# Altium schematic sheet ArduinoShield.SchDoc(ArduinoShield)
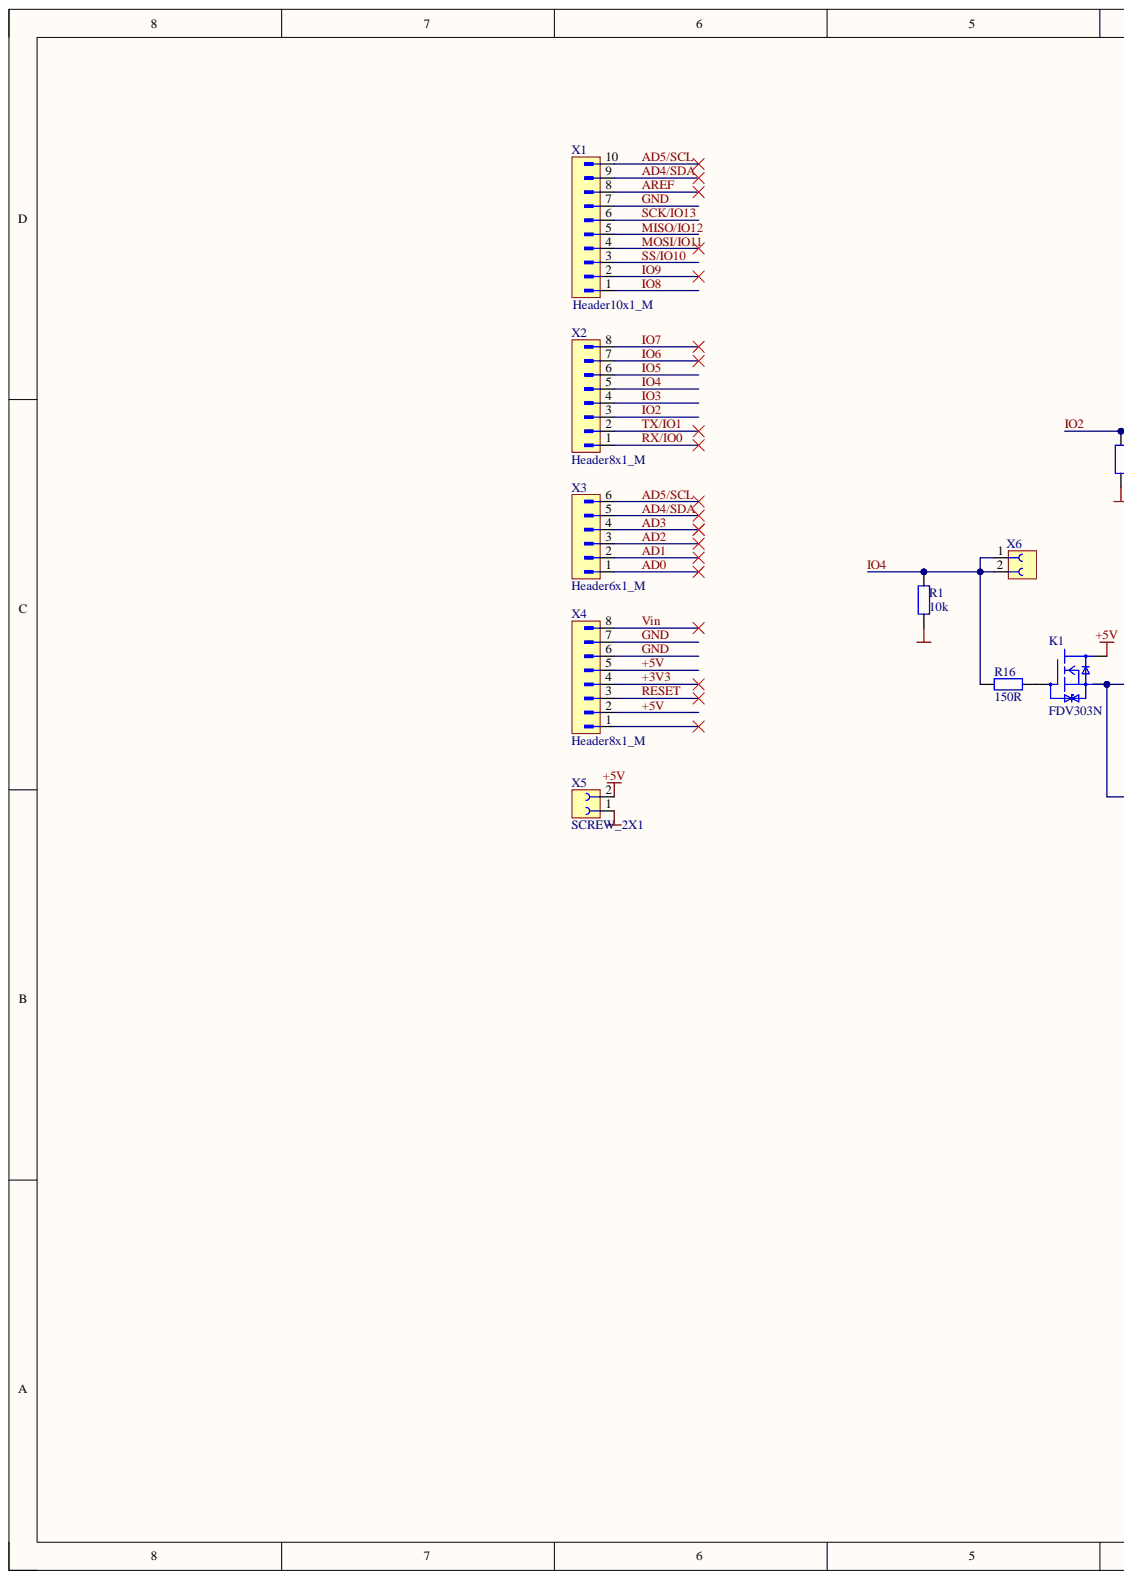
[diagram p0: ArduinoShield.SchDoc, left]
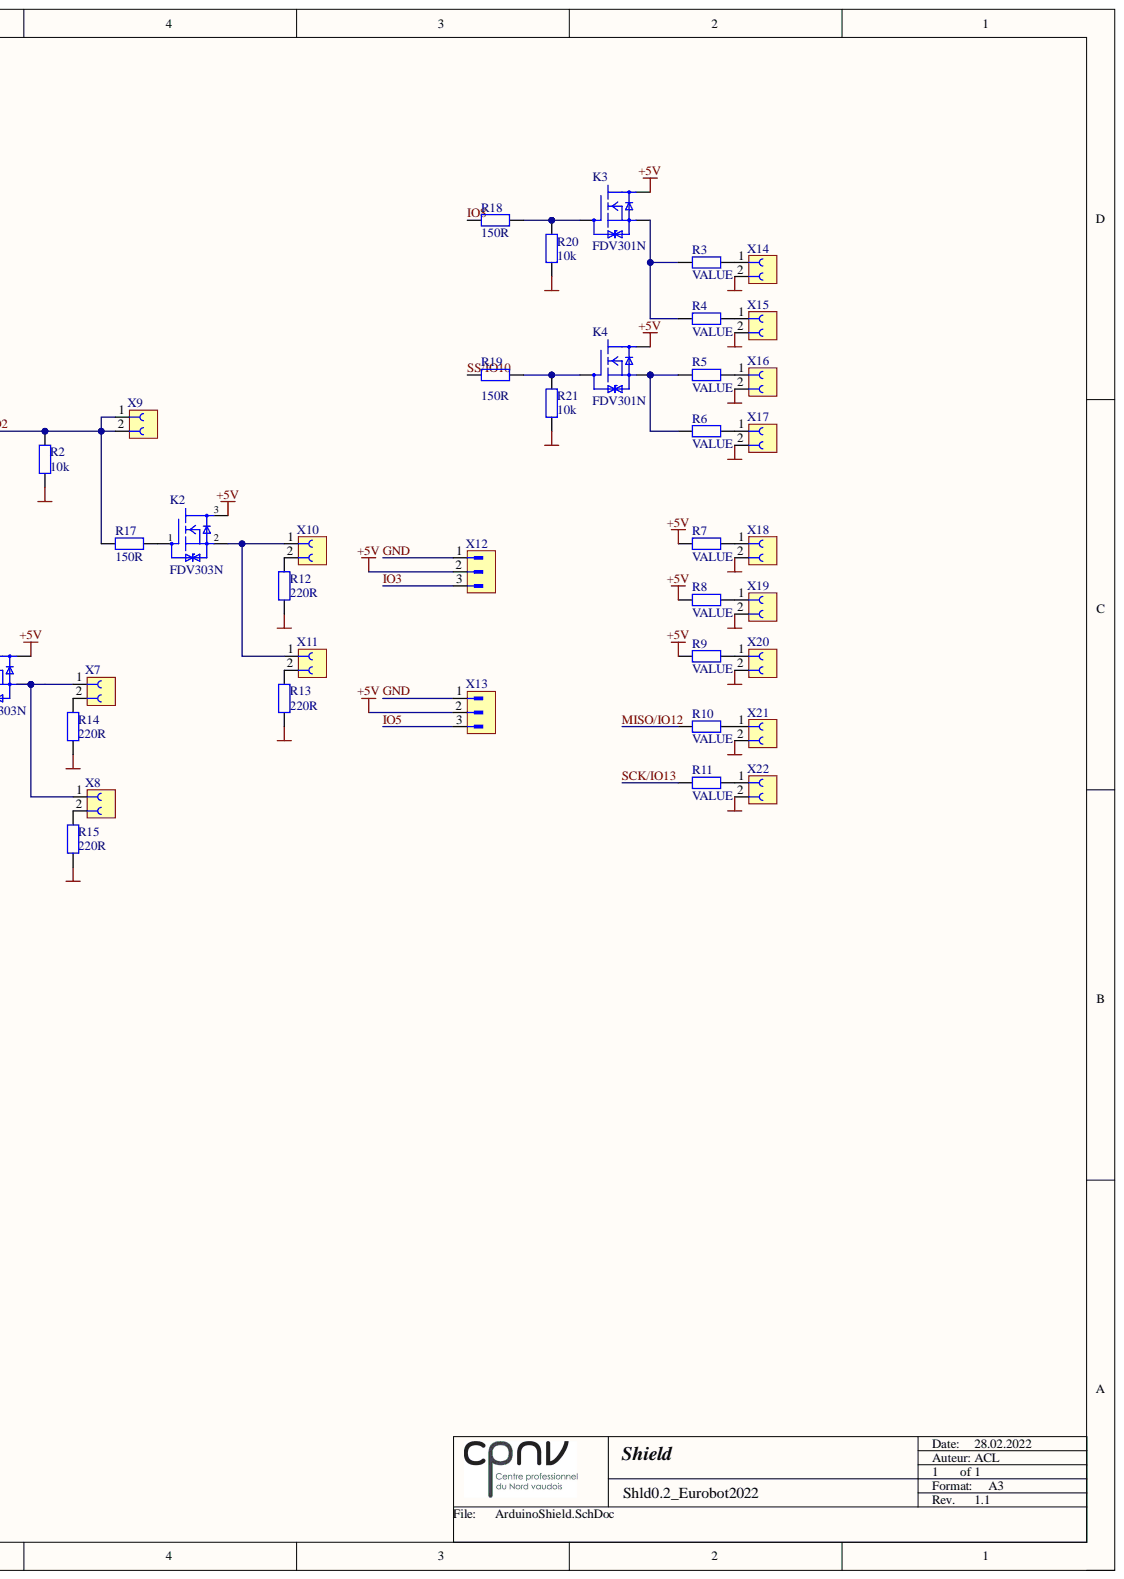
[diagram p1: ArduinoShield.SchDoc, right]

## Parts
K1  FDV303N  [SOT323]
K2  FDV303N  [SOT323]
K3  FDV301N  [SOT323]
K4  FDV301N  [SOT323]
R1  10k  [0603]
R2  10k  [0603]
R3  VALUE  [0603]
R4  VALUE  [0603]
R5  VALUE  [0603]
R6  VALUE  [0603]
R7  VALUE  [0603]
R8  VALUE  [0603]
R9  VALUE  [0603]
R10  VALUE  [0603]
R11  VALUE  [0603]
R12  220R  [0603]
R13  220R  [0603]
R14  220R  [0603]
R15  220R  [0603]
R16  150R  [0603]
R17  150R  [0603]
R18  150R  [0603]
R19  150R  [0603]
R20  10k  [0603]
R21  10k  [0603]
X1  Header10x1_M  [HDR10X1_M]
X2  Header8x1_M  [HDR8X1_M]
X3  Header6x1_M  [HDR6X1_M]
X4  Header8x1_M  [HDR8X1_M]
X5  SCREW_2X1  [691210910002]
X6  SCREW_2X1  [691210910002]
X7  SCREW_2X1  [691210910002]
X8  SCREW_2X1  [691210910002]
X9  SCREW_2X1  [691210910002]
X10  SCREW_2X1  [691210910002]
X11  SCREW_2X1  [691210910002]
X12  Header3x1_M  [HDR3X1_M]  (value not drawn)
X13  Header3x1_M  [HDR3X1_M]  (value not drawn)
X14  SCREW_2X1  [691210910002]
X15  SCREW_2X1  [691210910002]
X16  SCREW_2X1  [691210910002]
X17  SCREW_2X1  [691210910002]
X18  SCREW_2X1  [691210910002]
X19  SCREW_2X1  [691210910002]
X20  SCREW_2X1  [691210910002]
X21  SCREW_2X1  [691210910002]
X22  SCREW_2X1  [691210910002]

## Nets
+3V3: X4.4
+5V: K1.3 K2.3 K3.3 K4.3 R7.1 R8.1 R9.1 X4.2 X4.5 X5.2 X12.2 X13.2
AD0: X3.1
AD1: X3.2
AD2: X3.3
AD3: X3.4
AD4/SDA: X1.9 X3.5
AD5/SCL: X1.10 X3.6
AREF: X1.8
GND: R1.2 R2.2 R12.2 R13.2 R14.2 R15.2 R20.2 R21.2 X1.7 X4.6 X4.7 X5.1 X12.1 X13.1 X14.2 X15.2 X16.2 X17.2 X18.2 X19.2 X20.2 X21.2 X22.2
IO2: R2.1 R17.1 X2.3 X9.1 X9.2
IO3: X2.4 X12.3
IO4: R1.1 R16.1 X2.5 X6.1 X6.2
IO5: X2.6 X13.3
IO6: X2.7
IO7: X2.8
IO8: R18.1 X1.1
IO9: X1.2
MISO/IO12: R10.1 X1.5
MOSI/IO11: X1.4
RESET: X4.3
RX/IO0: X2.1
SCK/IO13: R11.1 X1.6
SS/IO10: R19.1 X1.3
TX/IO1: X2.2
Vin: X4.8
NetK1_1: K1.1 R16.2
NetK1_2: K1.2 X7.1 X8.1
NetK2_1: K2.1 R17.2
NetK2_2: K2.2 X10.1 X11.1
NetK3_1: K3.1 R18.2 R20.1
NetK3_2: K3.2 R3.1 R4.1
NetK4_1: K4.1 R19.2 R21.1
NetK4_2: K4.2 R5.1 R6.1
NetR3_2: R3.2 X14.1
NetR4_2: R4.2 X15.1
NetR5_2: R5.2 X16.1
NetR6_2: R6.2 X17.1
NetR7_2: R7.2 X18.1
NetR8_2: R8.2 X19.1
NetR9_2: R9.2 X20.1
NetR10_2: R10.2 X21.1
NetR11_2: R11.2 X22.1
NetR12_1: R12.1 X10.2
NetR13_1: R13.1 X11.2
NetR14_1: R14.1 X7.2
NetR15_1: R15.1 X8.2

Not connected: X4 1

## Library data of the parts
K1-K4 = Component_1: Component Kind: Standard; Library Name: Schlib1.SchLib
R1-R21 = RES: Resistor; Component Kind: Standard; Supplier 1: CPNV; Library Name: Passif_et_Semi-conducteurs.SchLib
X1 = CMP-031-000016-3: WURTH ELEKTRONIK - 61301011121 - Pin Header, Board-to-Board, 2.54 mm, 1 Rows, 10 Contacts, Through Hole, WR-PHD Series; Manufacturer: Wurth Electronics; Connector Type: Male Pin; Current Rating: 3A; Gender: Male; Insulation Resistance: 1GR; Number of Contacts: 10; Pitch: 2.54mm; Voltage Rating: 250V; Category: Headers and Wire Housings; Color: Black; Component Kind: Standard; ComponentLink1Description: https://datasheet.ciiva.com/738/6130xx11121-738550.pdf?src-supplier=Digi-Key; ComponentLink1URL: https://datasheet.ciiva.com/738/6130xx11121-738550.pdf?src-supplier=Digi-Key; ComponentLink2Description: https://datasheet.ciiva.com/7631/1442175-7631321.pdf?src-supplier=Element14; ComponentLink2URL: https://datasheet.ciiva.com/7631/1442175-7631321.pdf?src-supplier=Element14; ComponentLink3Description: https://datasheet.ciiva.com/48700/001088187-da-01-en-wr-phd-tht-stiftleiste-61301011121-48700876.pdf?src-supplier=Conrad; ComponentLink3URL: https://datasheet.ciiva.com/48700/001088187-da-01-en-wr-phd-tht-stiftleiste-61301011121-48700876.pdf?src-supplier=Conrad; Contact Gender: Male; Contact Material: Copper Alloy,Copper; Contact Mating Length: 0.236inch; Contact Plating: Gold; Contact Type: Male Pin; Design Item ID: Header10x1_M; Flammability Rating: UL94 V-0; Height: 11.54mm; Housing Material: PBT; Length: 2.54mm; Manufacturer Part Number: 61301011121; Max Operating Temperature: 125°C; Min Operating Temperature: -40°C; Mount: Through Hole; Number of Positions: 10; Number of Rows: 1; Orientation: Straight; Package Quantity: 1000; Packaging: Bulk; Pricing 1: 1=0.89000, 10=0.87400, 50=0.73900, 100=0.67200, 1000=0.42700, 10000=0.42700 (USD); Pricing 2: 1=0.89000, 10=0.87400, 50=0.80600, 100=0.73900, 200=0.67200 (USD); REACH SVHC: Unknown; RoHS: Yes; RoHS Compliant: Yes; Series: WR-PHD; Stock 1: 1900; Stock 2: 4313; Termination: Solder; Width: 25.4mm; Library Name: CPNV Centre professionnel du Nord vaudois; Source: MEION_Connectors.SchLib
X2 X4 = CMP-031-000015-3: Board-To-Board Connector, Vertical, Wr-Phd Series, Through Hole, Header, 8, 2.54 Mm; Manufacturer: Wurth Electronics; Manufacturer Part Number: 61300811121; Connector Type: Male Pin; Gender: Male; Number of Contacts: 8; Pitch: 0.1inch; Category: Connectors; Color: Black; Component Kind: Standard; ComponentLink1Description: http://www.farnell.com/cad/1737268.pdf?src-supplier=Element14; ComponentLink1URL: http://www.farnell.com/cad/1737268.pdf?src-supplier=Element14; ComponentLink2Description: https://katalog.we-online.de/em/datasheet/6130xx11121.pdf?src-supplier=Digi-Key; ComponentLink2URL: https://katalog.we-online.de/em/datasheet/6130xx11121.pdf?src-supplier=Digi-Key; Contact Material: Copper; Contact Mating Length: 0.236inch; Contact Plating: Gold; Design Item ID: Header8x1_M; Mount: Through Hole; Number of Positions: 8; Number of Rows: 1; Packaging: Bulk; Pricing 1: 1=0.37000, 10=0.31500, 50=0.21900, 100=0.19900, 1000=0.18100, 10000=0.18100 (USD); Pricing 2: 1=0.37000, 10=0.31500, 25=0.26320, 50=0.21900, 100=0.19900, 1000=0.18100 (USD); REACH SVHC: Unknown; RoHS: Yes; RoHS Compliant: Yes; Stock 1: 4202; Stock 2: 10891; Termination: Solder; Library Name: CPNV Centre professionnel du Nord vaudois; Source: MEION_Connectors.SchLib
X3 = CMP-031-000013-3: WURTH ELEKTRONIK - 61300611121 - Pin Header, Board-to-Board, 2.54 mm, 1 Rows, 6 Contacts, Through Hole, WR-PHD Series; Manufacturer: Wurth Electronics; Connector Type: Male Pin; Current Rating: 3A; Gender: Male; Number of Contacts: 6; Pitch: 0.1inch; Voltage Rating: 250V; Category: Headers and Wire Housings; Color: Black; Component Kind: Standard; ComponentLink1Description: http://www.mouser.com/ds/2/445/6130xx11121-538006.pdf?src-supplier=Mouser; ComponentLink1URL: http://www.mouser.com/ds/2/445/6130xx11121-538006.pdf?src-supplier=Mouser; ComponentLink2Description: https://katalog.we-online.de/em/datasheet/6130xx11121.pdf?src-supplier=Digi-Key; ComponentLink2URL: https://katalog.we-online.de/em/datasheet/6130xx11121.pdf?src-supplier=Digi-Key; ComponentLink3Description: http://www.farnell.com/cad/1737268.pdf?src-supplier=Element14; ComponentLink3URL: http://www.farnell.com/cad/1737268.pdf?src-supplier=Element14; Contact Gender: Male; Contact Material: Copper Alloy,Copper; Contact Mating Length: 0.236inch; Contact Plating: Gold; Design Item ID: Header6x1_M; Housing Material: PBT; Lead Free: Lead Free; Manufacturer Part Number: 61300611121; Max Operating Temperature: 125°C; Min Operating Temperature: -40°C; Mount: Through Hole; Number of Positions: 6; Number of Rows: 1; Packaging: Bulk; Pricing 1: 1=0.33000, 10=0.27900, 50=0.19400, 100=0.17600, 1000=0.16000, 10000=0.16000 (USD); Pricing 2: 1=0.33000, 10=0.27900, 25=0.23200, 50=0.19400, 100=0.17600, 1000=0.16000 (USD); REACH SVHC: Unknown; RoHS: Yes; Stock 1: 7278; Stock 2: 46170; Termination: Through Hole; Library Name: CPNV Centre professionnel du Nord vaudois; Source: MEION_Connectors.SchLib
X5-X11 X14-X22 = CMP-119-000000-3: WR-TBL Serie 2109 Horizontal Entry, Rising Cage Clamp, THT, pitch 2.54mm, 2p; Modularity: No; PCB/Cable/Panel: PCB; Pin Number: 2; Rated Current [UL]: 6A; Working Voltage [UL]: 150VAC; Application: Rising Cage Clamp; Component Kind: Standard; Component Type: Standard; ComponentLink1Description: Manufacturer; ComponentLink1URL: http://www.we-online.de; ComponentLink2Description: Datasheet; ComponentLink2URL: https://katalog.we-online.de/em/datasheet/691210910002.pdf; Design Item ID: 691210910002; Mounting Technology: THT; Operating Temperature: -40 to +105degC; Packaging: Box; Pin Count: 2; Pitch: 2.54mm; Published Date: Feburary 2021; Source: WR-TBL_Rising Cage Clamp.SchLib; Type: Horizontal; Library Name: CPNV Centre professionnel du Nord vaudois
X12 X13 = CMP-031-000005-4: WR-PHD 2.54 mm THT Pin Header; Manufacturer: Wurth Electronics; Manufacturer Part Number: 61300311121; Connector Type: Male Pin; Gender: Male; Number of Contacts: 3; Pitch: 0.1inch; Category: Connectors; Color: Black; Component Kind: Standard; ComponentLink1Description: http://www.farnell.com/cad/1737268.pdf?src-supplier=Element14; ComponentLink1URL: http://www.farnell.com/cad/1737268.pdf?src-supplier=Element14; ComponentLink2Description: https://katalog.we-online.de/em/datasheet/6130xx11121.pdf?src-supplier=Digi-Key; ComponentLink2URL: https://katalog.we-online.de/em/datasheet/6130xx11121.pdf?src-supplier=Digi-Key; Contact Material: Copper; Contact Mating Length: 0.236inch; Contact Plating: Gold; Design Item ID: Header3x1_M; LatestRevisionDate: 17-Jul-2002; LatestRevisionNote: Re-released for DXP Platform.; Lead Free: Lead Free; Mount: Through Hole; Number of Positions: 3; Number of Rows: 1; Packaging: Bulk; Price: 0; REACH SVHC: Unknown; RoHS: Yes; Stock 1: 52616; Termination: Solder; Library Name: CPNV Centre professionnel du Nord vaudois; Publisher: Altium Limited; Source: MEION_Connectors.SchLib
